# Altium schematic sheet External_Connectors.SchDoc
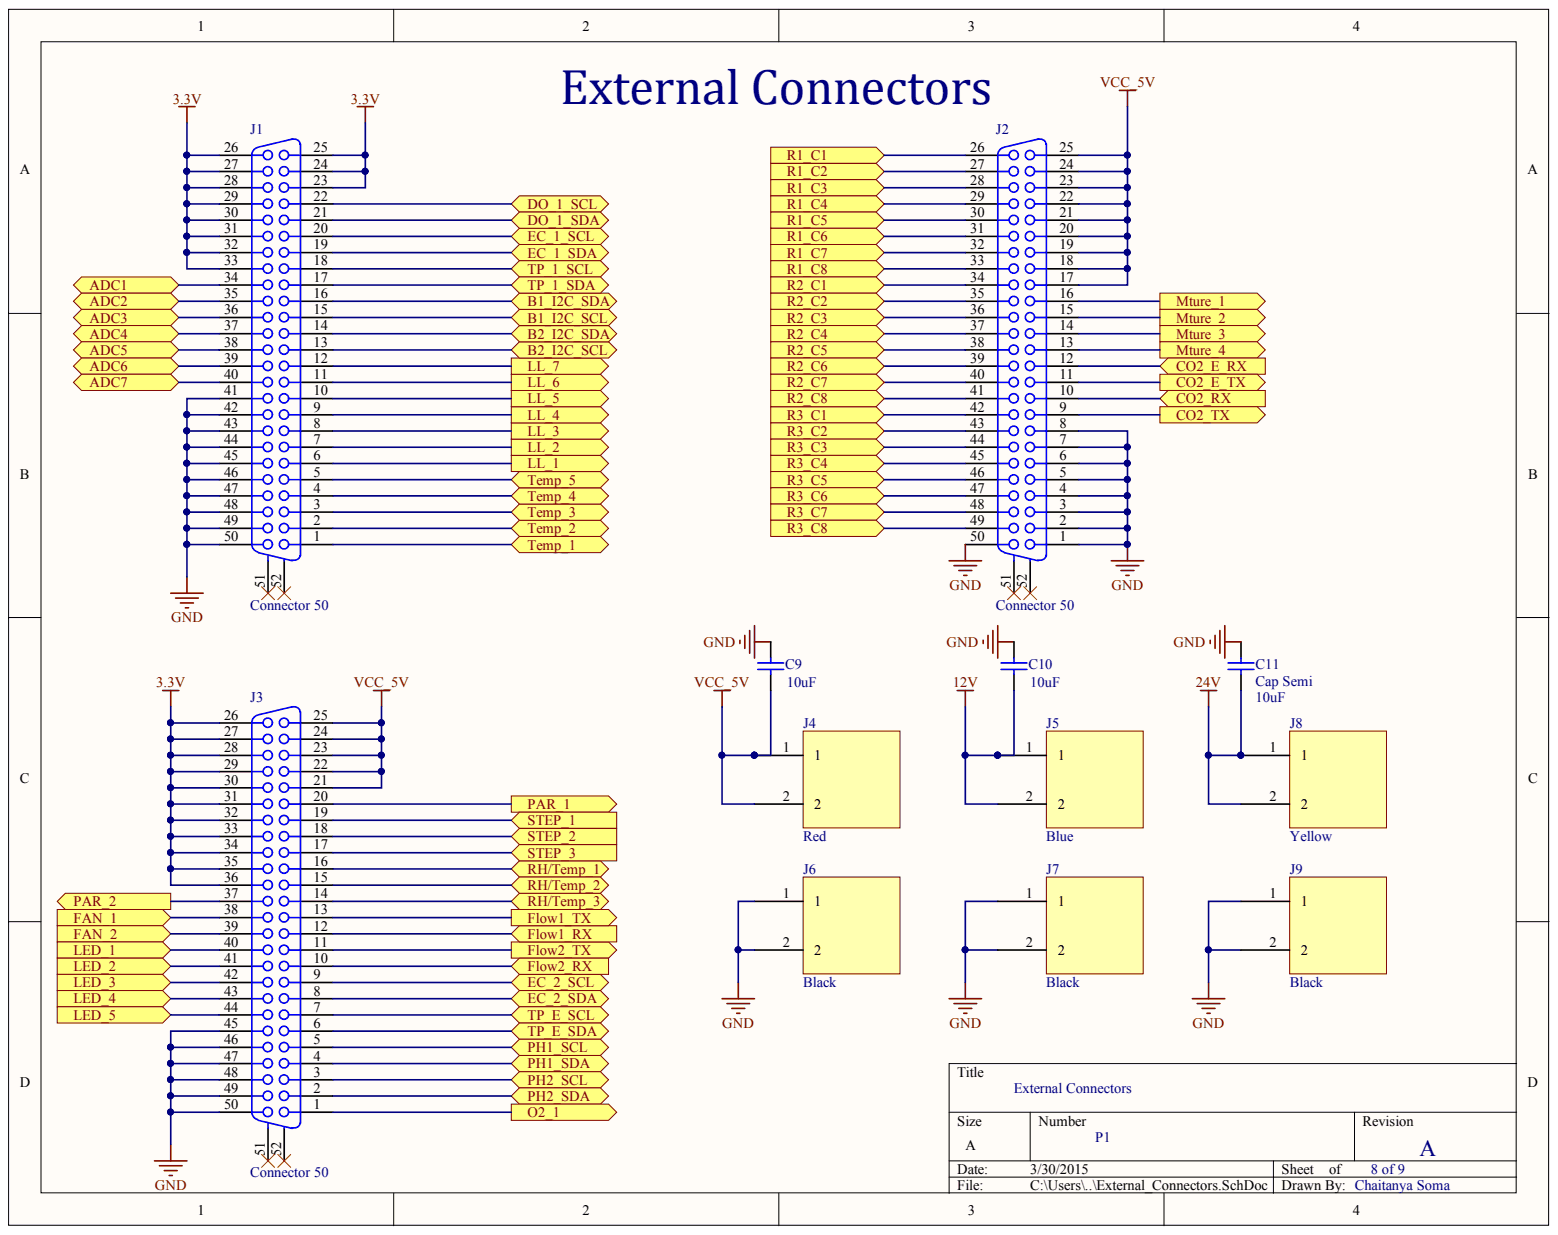
[diagram p0: External_Connectors.SchDoc]

## Parts
C9  value 10uF  [1608[0603]]  (hidden comment: Cap Semi)
C10  value 10uF  [1608[0603]]  (hidden comment: Cap Semi)
C11  Cap Semi  value 10uF  [1608[0603]]
J1  Connector 50  [CHAMP1.27-2H50]
J2  Connector 50  [CHAMP1.27-2H50]
J3  Connector 50  [CHAMP1.27-2H50]
J4  Red  [3-582118-0-ND]
J5  Blue  [3-582118-0-ND]
J6  Black  [3-582118-0-ND]
J7  Black  [3-582118-0-ND]
J8  Yellow  [3-582118-0-ND]
J9  Black  [3-582118-0-ND]

## Ports
ADC1, ADC2, ADC3, ADC4, ADC5, ADC6, ADC7, B1_I2C_SCL, B1_I2C_SDA, B2_I2C_SCL, B2_I2C_SDA, CO2_E_RX, CO2_E_TX, CO2_RX, CO2_TX, DO_1_SCL, DO_1_SDA, EC_1_SCL, EC_1_SDA, EC_2_SCL, EC_2_SDA, FAN_1, FAN_2, FLOW1_RX, FLOW1_TX, FLOW2_RX, FLOW2_TX, LED_1, LED_2, LED_3, LED_4, LED_5, LL_1, LL_2, LL_3, LL_4, LL_5, LL_6, LL_7, MTURE_1, MTURE_2, MTURE_3, MTURE_4, O2_1, PAR_1, PAR_2, PH1_SCL, PH1_SDA, PH2_SCL, PH2_SDA, R1_C1, R1_C2, R1_C3, R1_C4, R1_C5, R1_C6, R1_C7, R1_C8, R2_C1, R2_C2, R2_C3, R2_C4, R2_C5, R2_C6, R2_C7, R2_C8, R3_C1, R3_C2, R3_C3, R3_C4, R3_C5, R3_C6, R3_C7, R3_C8, RH/TEMP_1, RH/TEMP_2, RH/TEMP_3, STEP_1, STEP_2, STEP_3, TEMP_1, TEMP_2, TEMP_3, TEMP_4, TEMP_5, TP_1_SCL, TP_1_SDA, TP_E_SCL, TP_E_SDA

## Nets
3.3V: J1.23 J1.24 J1.25 J1.26 J1.27 J1.28 J1.29 J1.30 J1.31 J1.32 J1.33 J3.26 J3.27 J3.28 J3.29 J3.30 J3.31 J3.32 J3.33 J3.34 J3.35 J3.36
12V: C10.1 J5.1 J5.2
24V: C11.1 J8.1 J8.2
GND: C9.2 C10.2 C11.2 J1.41 J1.42 J1.43 J1.44 J1.45 J1.46 J1.47 J1.48 J1.49 J1.50 J2.1 J2.2 J2.3 J2.4 J2.5 J2.6 J2.7 J2.8 J2.50 J3.45 J3.46 J3.47 J3.48 J3.49 J3.50 J6.1 J6.2 J7.1 J7.2 J9.1 J9.2
VCC_5V: C9.1 J2.17 J2.18 J2.19 J2.20 J2.21 J2.22 J2.23 J2.24 J2.25 J3.21 J3.22 J3.23 J3.24 J3.25 J4.1 J4.2
ADC1: J1.34 ; port ADC1
ADC2: J1.35 ; port ADC2
ADC3: J1.36 ; port ADC3
ADC4: J1.37 ; port ADC4
ADC5: J1.38 ; port ADC5
ADC6: J1.39 ; port ADC6
ADC7: J1.40 ; port ADC7
B1_I2C_SCL: J1.15 ; port B1_I2C_SCL
B1_I2C_SDA: J1.16 ; port B1_I2C_SDA
B2_I2C_SCL: J1.13 ; port B2_I2C_SCL
B2_I2C_SDA: J1.14 ; port B2_I2C_SDA
CO2_E_RX: J2.12 ; port CO2_E_RX
CO2_E_TX: J2.11 ; port CO2_E_TX
CO2_RX: J2.10 ; port CO2_RX
CO2_TX: J2.9 ; port CO2_TX
DO_1_SCL: J1.22 ; port DO_1_SCL
DO_1_SDA: J1.21 ; port DO_1_SDA
EC_1_SCL: J1.20 ; port EC_1_SCL
EC_1_SDA: J1.19 ; port EC_1_SDA
EC_2_SCL: J3.9 ; port EC_2_SCL
EC_2_SDA: J3.8 ; port EC_2_SDA
FAN_1: J3.38 ; port FAN_1
FAN_2: J3.39 ; port FAN_2
LED_1: J3.40 ; port LED_1
LED_2: J3.41 ; port LED_2
LED_3: J3.42 ; port LED_3
LED_4: J3.43 ; port LED_4
LED_5: J3.44 ; port LED_5
LL_1: J1.6 ; port LL_1
LL_2: J1.7 ; port LL_2
LL_3: J1.8 ; port LL_3
LL_4: J1.9 ; port LL_4
LL_5: J1.10 ; port LL_5
LL_6: J1.11 ; port LL_6
LL_7: J1.12 ; port LL_7
O2_1: J3.1 ; port O2_1
PAR_1: J3.20 ; port PAR_1
PAR_2: J3.37 ; port PAR_2
PH1_SCL: J3.5 ; port PH1_SCL
PH1_SDA: J3.4 ; port PH1_SDA
PH2_SCL: J3.3 ; port PH2_SCL
PH2_SDA: J3.2 ; port PH2_SDA
R1_C1: J2.26 ; port R1_C1
R1_C2: J2.27 ; port R1_C2
R1_C3: J2.28 ; port R1_C3
R1_C4: J2.29 ; port R1_C4
R1_C5: J2.30 ; port R1_C5
R1_C6: J2.31 ; port R1_C6
R1_C7: J2.32 ; port R1_C7
R1_C8: J2.33 ; port R1_C8
R2_C1: J2.34 ; port R2_C1
R2_C2: J2.35 ; port R2_C2
R2_C3: J2.36 ; port R2_C3
R2_C4: J2.37 ; port R2_C4
R2_C5: J2.38 ; port R2_C5
R2_C6: J2.39 ; port R2_C6
R2_C7: J2.40 ; port R2_C7
R2_C8: J2.41 ; port R2_C8
R3_C1: J2.42 ; port R3_C1
R3_C2: J2.43 ; port R3_C2
R3_C3: J2.44 ; port R3_C3
R3_C4: J2.45 ; port R3_C4
R3_C5: J2.46 ; port R3_C5
R3_C6: J2.47 ; port R3_C6
R3_C7: J2.48 ; port R3_C7
R3_C8: J2.49 ; port R3_C8
STEP_1: J3.19 ; port STEP_1
STEP_2: J3.18 ; port STEP_2
STEP_3: J3.17 ; port STEP_3
TP_1_SCL: J1.18 ; port TP_1_SCL
TP_1_SDA: J1.17 ; port TP_1_SDA
TP_E_SCL: J3.7 ; port TP_E_SCL
TP_E_SDA: J3.6 ; port TP_E_SDA
NetJ1_1: J1.1 ; port TEMP_1
NetJ1_2: J1.2 ; port TEMP_2
NetJ1_3: J1.3 ; port TEMP_3
NetJ1_4: J1.4 ; port TEMP_4
NetJ1_5: J1.5 ; port TEMP_5
NetJ2_13: J2.13 ; port MTURE_4
NetJ2_14: J2.14 ; port MTURE_3
NetJ2_15: J2.15 ; port MTURE_2
NetJ2_16: J2.16 ; port MTURE_1
NetJ3_10: J3.10 ; port FLOW2_RX
NetJ3_11: J3.11 ; port FLOW2_TX
NetJ3_12: J3.12 ; port FLOW1_RX
NetJ3_13: J3.13 ; port FLOW1_TX
NetJ3_14: J3.14 ; port RH/TEMP_3
NetJ3_15: J3.15 ; port RH/TEMP_2
NetJ3_16: J3.16 ; port RH/TEMP_1

Not connected: J1 51 52; J2 51 52; J3 51 52

## Library data of the parts
C9 C10 = Cap Semi: Capacitor (Semiconductor SIM Model); Component Cost: 0.30000; Component Link Descriptions: CAP CER 10UF 16V 20% X5R 0603; Component Kind: Standard; Component Link URL: http://www.digikey.com/product-detail/en/GRM188R61C106MA73D/490-7201-1-ND/3900482; Digikey PN: 490-7201-1-ND; LatestRevisionDate: 29-May-2009; LatestRevisionNote: IPC-7351 Footprint Added.; Library Name: Miscellaneous Devices.IntLib; PackageDescription: Chip Capacitor; PackageReference: C1206; Published: 8-Jun-2000; Signal Integrity: Cap Semi; Simulation: CAP; Publisher: Altium Limited
C11 = Cap Semi: Capacitor (Semiconductor SIM Model); Component Cost: 0.89000; Component Link Descriptions: CAP CER 10UF 25V 20% X5R 0603; Component Kind: Standard; Component Link URL: http://www.digikey.com/product-detail/en/C1608X5R1E106M080AC/445-9015-1-ND/3648665; Digikey PN: 445-9015-1-ND; LatestRevisionDate: 29-May-2009; LatestRevisionNote: IPC-7351 Footprint Added.; Library Name: Miscellaneous Devices.IntLib; PackageDescription: Chip Capacitor; PackageReference: C1206; Published: 8-Jun-2000; Signal Integrity: Cap Semi; Simulation: CAP; Publisher: Altium Limited
J1-J3 = Connector 50: Receptacle Header Assemby; Component Cost: 4.78000; Component Link Descriptions: CONN D-TYPE RCPT 50POS R/A SLDR; PackageDocument: B; Positions: 50; Component Kind: Standard; Component Link URL: http://www.digikey.com/product-detail/en/5787082-5/A31812-ND/807161; DatasheetDocument: 1-Oct-1983; Digikey PN: A31812-ND; LatestRevisionDate: 17-Jul-2002; LatestRevisionNote: Re-released for DXP Platform.; Library Name: Miscellaneous Connectors.IntLib; Orientation: Right Angle; PackageReference: 178240-7; Published: 15-Jun-2001; Signal Integrity: Connector; Publisher: Altium Limited
J4 = Banana Sockete: Component Cost: 2.61000; Component Link Descriptions: CONN TEST PROBE GOLD RED PCB; Component Kind: Standard; Component Link URL: http://www.digikey.com/product-detail/en/3-582118-2/3-582118-2-ND/292605; Digikey PN: 3-582118-2-ND; Library Name: Power.SchLib
J5 = Banana Sockete: Component Cost: 3.04000; Component Link Descriptions: CONN TEST PROBE GOLD BLUE PCB; Component Kind: Standard; Component Link URL: http://www.digikey.com/product-detail/en/3-582118-6/A113498-ND/292607; Digikey PN: A113498-ND; Library Name: Power.SchLib
J6 J7 J9 = Banana Sockete: Component Cost: 2.69000; Component Link Descriptions: CONN TEST PROBE GOLD BLACK PCB; Component Kind: Standard; Component Link URL: http://www.digikey.com/product-detail/en/3-582118-0/3-582118-0-ND/299283; Digikey PN: 3-582118-0-ND; Library Name: Power.SchLib
J8 = Banana Sockete: Component Cost: 3.04000; Component Link Descriptions: CONN TEST PROBE GOLD YEL PCB; Component Kind: Standard; Component Link URL: http://www.digikey.com/product-detail/en/3-582118-4/A113497-ND/292606; Digikey PN: A113497-ND; Library Name: Power.SchLib
